# Altium schematic sheet Sheet1.SchDoc
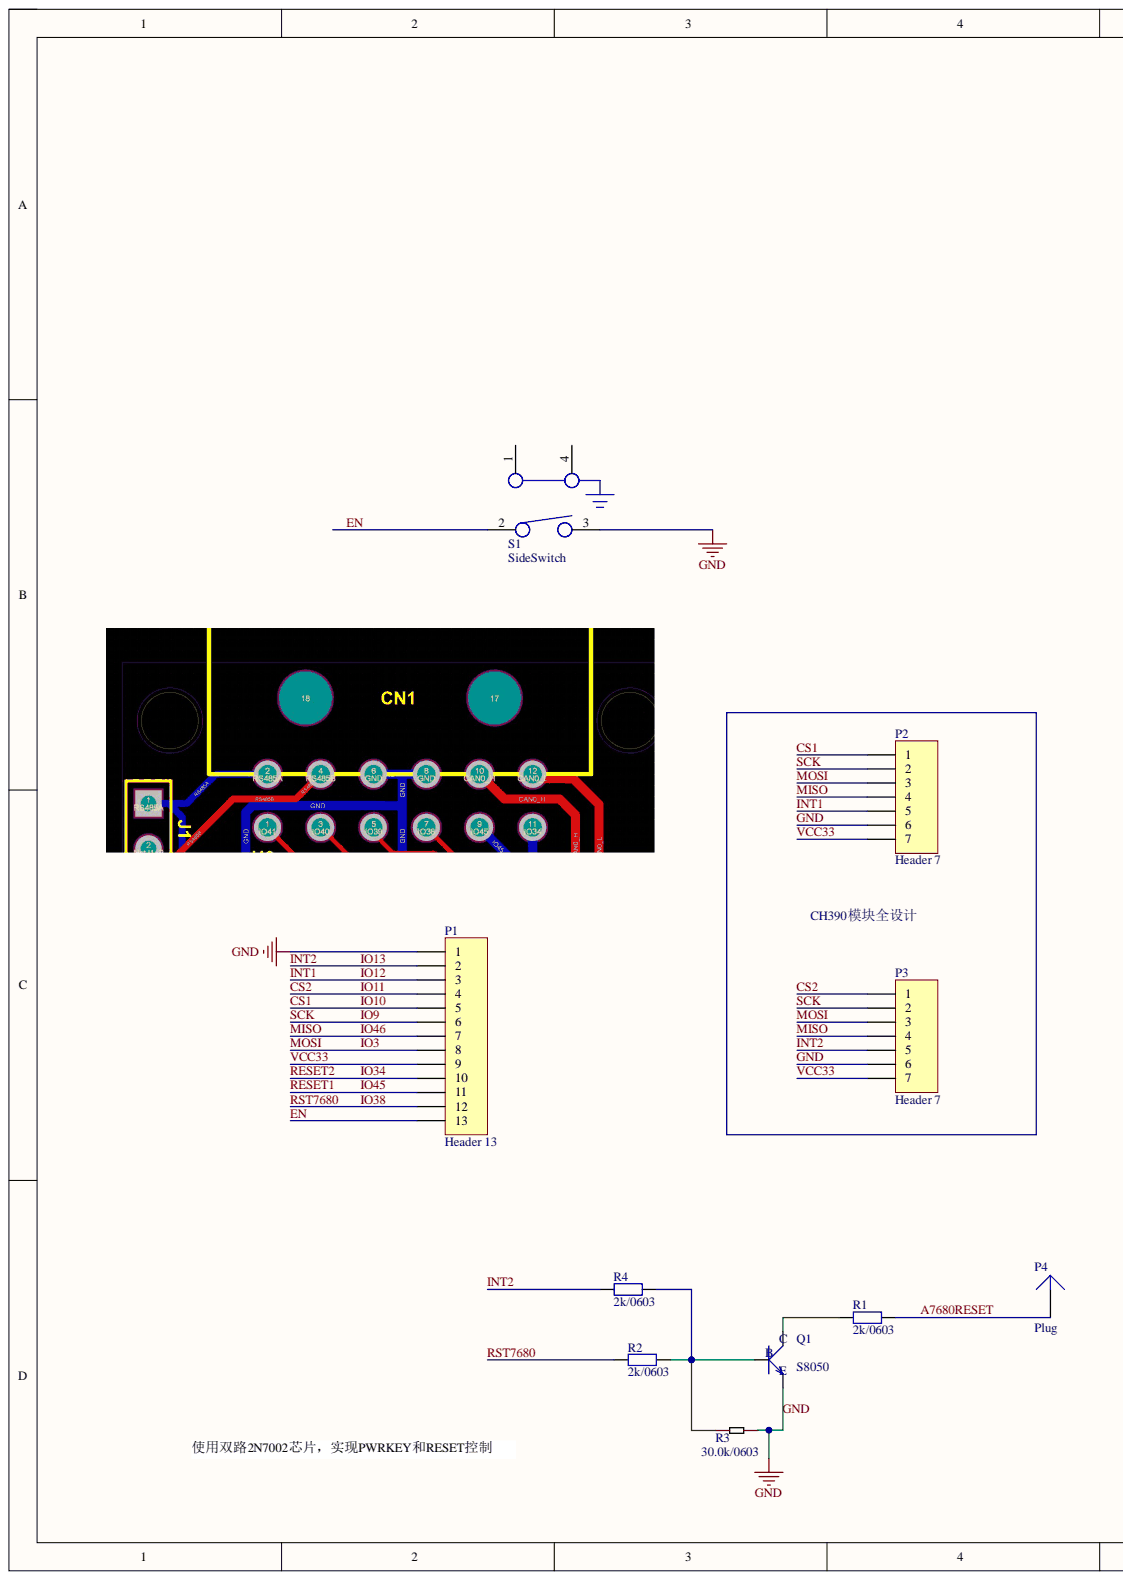
[diagram p0: Sheet1.SchDoc, left]
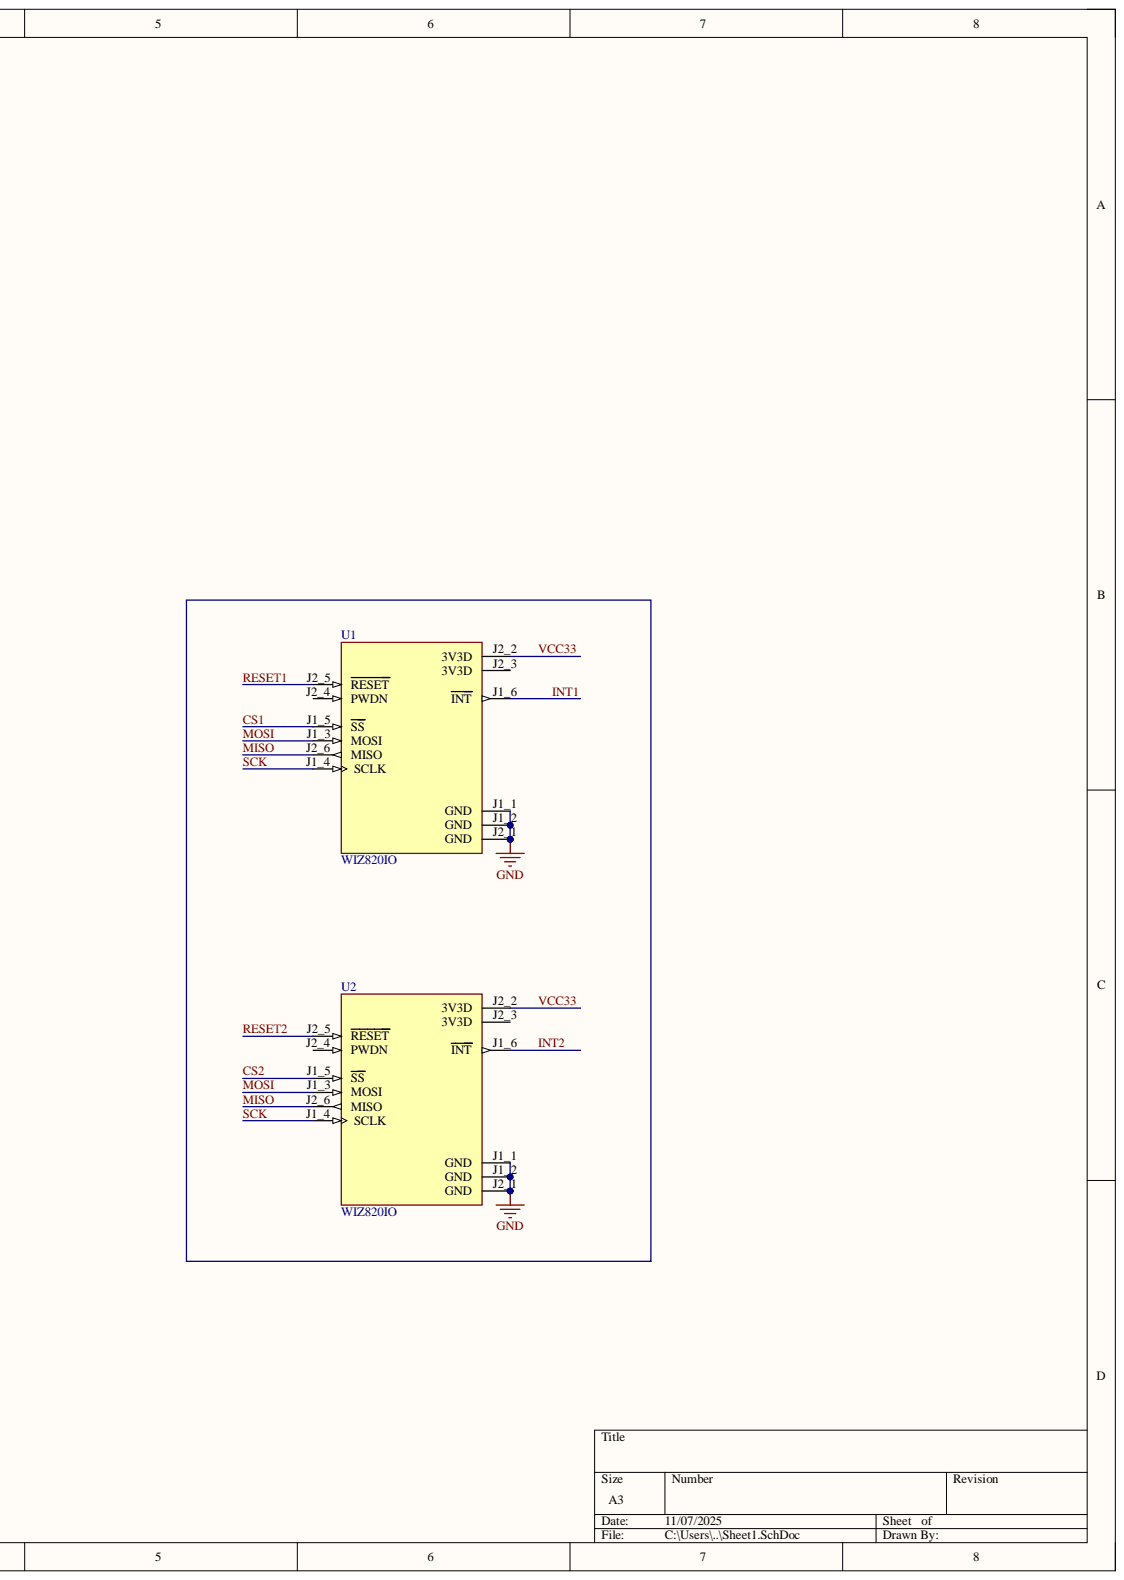
[diagram p1: Sheet1.SchDoc, right]

## Parts
P1  Header 13  [HDR1X13]
P2  Header 7  [HDR1X7]
P3  Header 7  [HDR1X7]
P4  Plug  [PIN1]
Q1  S8050  [SOT-23]
R1  2k/0603  [RES0603]
R2  2k/0603  [RES0603]
R3  30.0k/0603  [RES0603]
R4  2k/0603  [RES0603]
S1  SideSwitch  [SideSwitch]
U1  WIZ820IO  [MODULE_WIZ820IO]
U2  WIZ820IO  [MODULE_WIZ820IO]

## Nets
A7680RESET: P4.1 R1.2
CS1: P1.5 P2.1 U1.J1_5(SS)
CS2: P1.4 P3.1 U2.J1_5(SS)
EN: P1.13 S1.2
GND: P1.1 P2.6 P3.6 Q1.2 R3.1 S1.3 U1.J1_1(GND) U1.J1_2(GND) U1.J2_1(GND) U2.J1_1(GND) U2.J1_2(GND) U2.J2_1(GND)
INT1: P1.3 P2.5 U1.J1_6(INT)
INT2: P1.2 P3.5 R4.1 U2.J1_6(INT)
IO3: P1.8 P2.3 P3.3 U1.J1_3(MOSI) U2.J1_3(MOSI)
IO9: P1.6 P2.2 P3.2 U1.J1_4(SCLK) U2.J1_4(SCLK)
IO34: P1.10 U2.J2_5(RESET)
IO38: P1.12 R2.1
IO45: P1.11 U1.J2_5(RESET)
IO46: P1.7 P2.4 P3.4 U1.J2_6(MISO) U2.J2_6(MISO)
VCC33: P1.9 P2.7 P3.7 U1.J2_2(3V3D) U2.J2_2(3V3D)
NetQ1_1: Q1.1 R2.2 R3.2 R4.2
NetQ1_3: Q1.3 R1.1

Not connected: S1 1 4; U1 J2_3(3V3D) J2_4(PWDN); U2 J2_3(3V3D) J2_4(PWDN)

## Library data of the parts
P1 = Header 13: Header, 13-Pin; Component Kind: Standard; LatestRevisionDate: 17-Jul-2002; LatestRevisionNote: Re-released for DXP Platform.; Library Name: Miscellaneous Connectors.IntLib; Signal Integrity: Connector; Publisher: Altium Limited
P2 P3 = Header 7: Header, 7-Pin; Component Kind: Standard; LatestRevisionDate: 17-Jul-2002; LatestRevisionNote: Re-released for DXP Platform.; Library Name: Miscellaneous Connectors.IntLib; Signal Integrity: Connector; Publisher: Altium Limited
Q1 = SMD-TRANSISTORS-NPN-25V-500MW(SOT-23): Bipolar (BJT) Transistor NPN 25 V 500 mA 150MHz 300 mW Surface Mount SOT-23; MF: UMW; Availability: In Stock; Check_prices: https://www.snapeda.com/parts/S8050/Umwelt+Sensor+Teknik/view-part/?ref=eda; Component Kind: Standard; Library Name: SMD-TRANSISTORS-NPN-25V-500MW(SOT-23).SchLib; MP: S8050; Package: None; Price: None; Purchase-URL: https://pricing.snapeda.com/search/part/S8050/?ref=eda; SnapEDA_Link: https://www.snapeda.com/parts/S8050/Umwelt+Sensor+Teknik/view-part/?ref=snap
R1 R2 R4 = Res2: Component Kind: Standard; LatestRevisionDate: 17-Jul-2002; LatestRevisionNote: Re-released for DXP Platform.; Library Name: Miscellaneous Devices.IntLib; PackageDescription: Axial Device, Thru-Hole; 2 Leads; 0.4 in Pin Spacing; PackageReference: AXIAL-0.4; Published: 8-Jun-2000; Signal Integrity: Res; Simulation: RESISTOR; Publisher: Altium Limited
R3 = Discrete_R*_-0603: Add into BOM: yes; Name: 47K/0603; Origin Footprint: R0603; Component Kind: Standard; Convert to PCB: yes; Device: Discrete_R*_-0603; Symbol: Discrete_R*_-0603
S1 = SideSwitch: Tactile Switch SPST-NO Side Actuated Through Hole, Right Angle; MF: Wurth Elektronik; MP: 431256038726; Availability: In Stock; Check_prices: https://www.snapeda.com/parts/431256038726/Wurth+Elektronik/view-part/?ref=eda; Component Kind: Standard; Package: None; Price: None; Purchase-URL: https://pricing.snapeda.com/search/part/431256038726/?ref=eda; SnapEDA_Link: https://www.snapeda.com/parts/431256038726/Wurth+Elektronik/view-part/?ref=snap; Library Name: ESPC6IOTALLinOne.SCHLIB
U1 U2 = WIZ820IO: Ethernet Controller 10/100 Base-T/TX PHY SPI Interface Module; MF: WIZnet; Check_prices: https://www.snapeda.com/parts/WIZ820IO/WIZnet/view-part/?ref=eda; Component Kind: Standard; Library Name: WIZ820IO.SchLib; MP: WIZ820IO; Package: Module WIZnet; SnapEDA_Link: https://www.snapeda.com/parts/WIZ820IO/WIZnet/view-part/?ref=snap
P4 = Plug: Component Kind: Standard; Description: Plug; LatestRevisionDate: 17-Jul-2002; LatestRevisionNote: Re-released for DXP Platform.; Library Name: Miscellaneous Connectors.IntLib; Signal Integrity: Connector; Publisher: Altium Limited
